# Altium schematic sheet Microcontroller.SchDoc
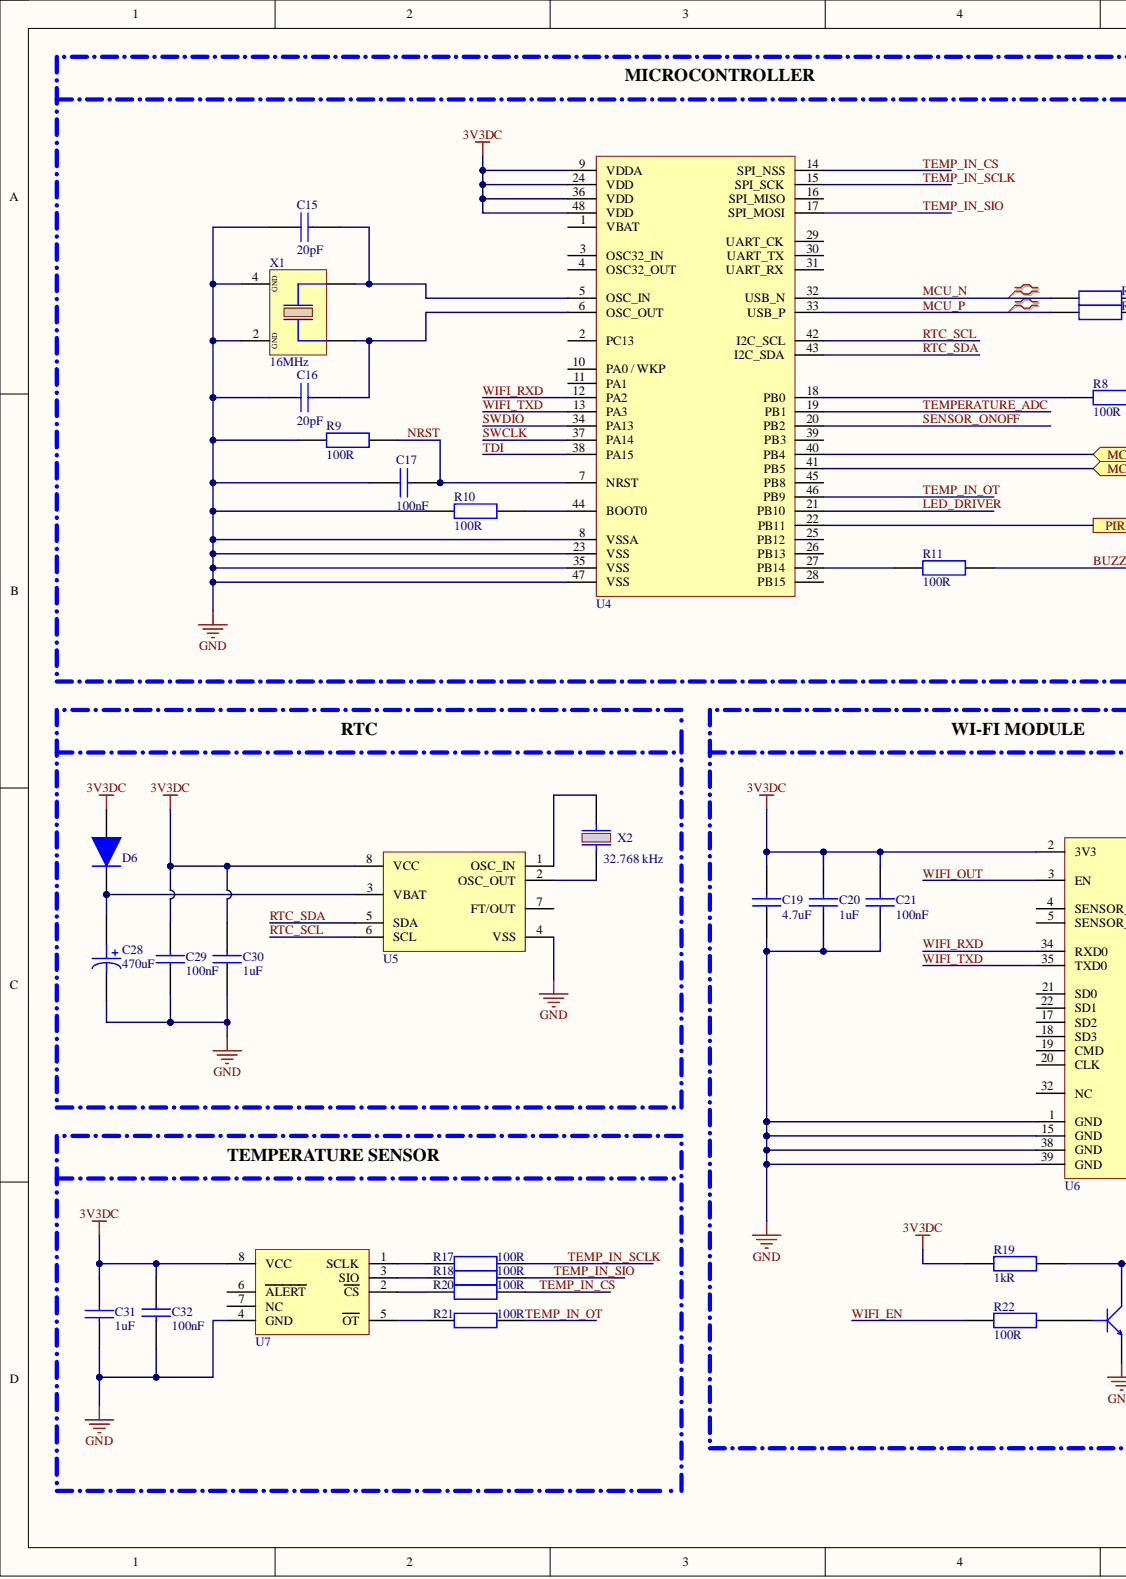
[diagram p0: Microcontroller.SchDoc, left]
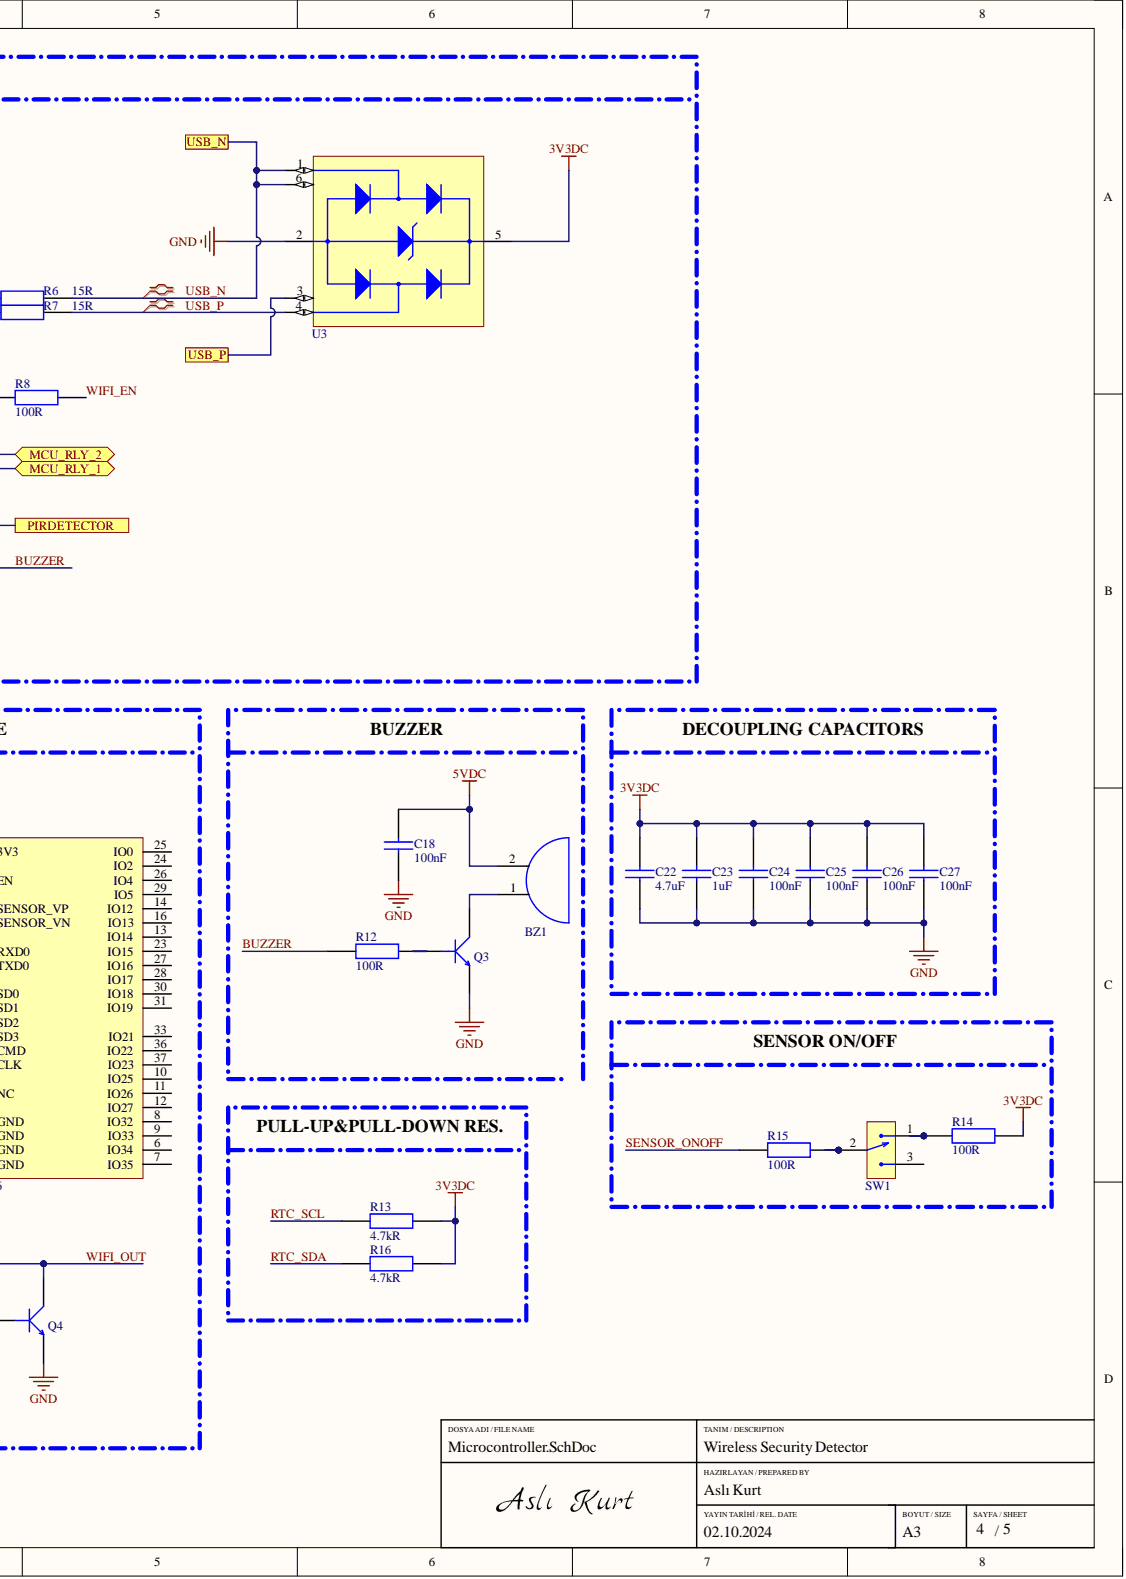
[diagram p1: Microcontroller.SchDoc, right]

## Parts
BZ1  CMI-9605IC-0580T  [CMI-9605IC]  (value not drawn)
C15  Capacitance: 20pF  [CAP_0603]  (hidden comment: CL10C200JB8NNNC)
C16  Capacitance: 20pF  [CAP_0603]  (hidden comment: CL10C200JB8NNNC)
C17  Capacitance: 100nF  [CAP_0603]  (hidden comment: C0603C104K5RACTU)
C18  Capacitance: 100nF  [CAP_0805]  (hidden comment: CL21B104KBCNNNC)
C19  Capacitance: 4.7uF  [CAP_0805]  (hidden comment: CL21A475KAQNNNE)
C20  Capacitance: 1uF  [CAP_0805]  (hidden comment: CL21B105KBFNNNE)
C21  Capacitance: 100nF  [CAP_0805]  (hidden comment: CL21B104KBCNNNC)
C22  Capacitance: 4.7uF  [CAP_0805]  (hidden comment: CL21A475KAQNNNE)
C23  Capacitance: 1uF  [CAP_0805]  (hidden comment: CL21B105KBFNNNE)
C24  Capacitance: 100nF  [CAP_0603]  (hidden comment: C0603C104K5RACTU)
C25  Capacitance: 100nF  [CAP_0603]  (hidden comment: C0603C104K5RACTU)
C26  Capacitance: 100nF  [CAP_0603]  (hidden comment: C0603C104K5RACTU)
C27  Capacitance: 100nF  [CAP_0603]  (hidden comment: C0603C104K5RACTU)
C28  Capacitance: 470uF  [CAP_RADIAL_D10_P5]  (hidden comment: ESH477M050AH4AA)
C29  Capacitance: 100nF  [CAP_0805]  (hidden comment: CL21B104KBCNNNC)
C30  Capacitance: 1uF  [CAP_0805]  (hidden comment: CL21B105KBFNNNE)
C31  Capacitance: 1uF  [CAP_0805]  (hidden comment: CL21B105KBFNNNE)
C32  Capacitance: 100nF  [CAP_0805]  (hidden comment: CL21B104KBCNNNC)
D6  1N4148WS  [SOD323F]  (value not drawn)
Q3  MMBT3904-7-F  [SOT-23F]  (value not drawn)
Q4  MMBT3904-7-F  [SOT-23F]  (value not drawn)
R6  Resistance: 15R  [RES_0603]  (hidden comment: RC0603JR-0715RL)
R7  Resistance: 15R  [RES_0603]  (hidden comment: RC0603JR-0715RL)
R8  Resistance: 100R  [RES_0603]  (hidden comment: RC0603JR-07100RL)
R9  Resistance: 100R  [RES_0603]  (hidden comment: RC0603JR-07100RL)
R10  Resistance: 100R  [RES_0603]  (hidden comment: RC0603JR-07100RL)
R11  Resistance: 100R  [RES_0603]  (hidden comment: RC0603JR-07100RL)
R12  Resistance: 100R  [RES_0603]  (hidden comment: RC0603JR-07100RL)
R13  Resistance: 4.7kR  [RES_0603]  (hidden comment: RC0603JR-074K7L)
R14  Resistance: 100R  [RES_0603]  (hidden comment: RC0603JR-07100RL)
R15  Resistance: 100R  [RES_0603]  (hidden comment: RC0603JR-07100RL)
R16  Resistance: 4.7kR  [RES_0603]  (hidden comment: RC0603JR-074K7L)
R17  Resistance: 100R  [RES_0603]  (hidden comment: RC0603JR-07100RL)
R18  Resistance: 100R  [RES_0603]  (hidden comment: RC0603JR-07100RL)
R19  Resistance: 1kR  [RES_0603]  (hidden comment: RC0603FR-0710KL)
R20  Resistance: 100R  [RES_0603]  (hidden comment: RC0603JR-07100RL)
R21  Resistance: 100R  [RES_0603]  (hidden comment: RC0603JR-07100RL)
R22  Resistance: 100R  [RES_0603]  (hidden comment: RC0603JR-07100RL)
SW1  EG1215AA  [EG1215AA]  (value not drawn)
U3  USBLC6-2SC6  [SOT23-6L]  (value not drawn)
U4  STM32F103C6T6A  [LQFP-48]  (value not drawn)
U5  M41T00M6F  [SOIC8]  (value not drawn)
U6  Supply Voltage-Nom: 3V3  [ESP32-32]  (hidden comment: ESP32-WROOM-32)
U7  MAX6662  [SOIC8]  (value not drawn)
X1  Frequency: 16MHz  [ECS]  (hidden comment: ECS-160-20-30B-TR)
X2  Operating Frequency: 32.768 kHz  [ABS05]  (hidden comment: ABS05-32.768KHZ-T)

## Ports
MCU_RLY_1, MCU_RLY_2, PIRDETECTOR, USB_N, USB_P

## Nets
3V3DC: C19.2 C20.2 C21.2 C22.2 C23.2 C24.2 C25.2 C26.2 C27.2 C29.2 C30.2 C31.2 C32.1 D6.2 R13.2 R14.2 R16.2 R19.1 U3.5 U4.9(VDDA) U4.24(VDD) U4.36(VDD) U4.48(VDD) U5.8(VCC) U6.2(3V3) U7.8(VCC)
5VDC: BZ1.2 C18.2
GND: C15.1 C16.1 C17.1 C18.1 C19.1 C20.1 C21.1 C22.1 C23.1 C24.1 C25.1 C26.1 C27.1 C28.2 C29.1 C30.1 C31.1 C32.2 Q3.2 Q4.2 R9.1 R10.1 U3.2 U4.8(VSSA) U4.23(VSS) U4.35(VSS) U4.47(VSS) U5.4(VSS) U6.1(GND) U6.15(GND) U6.38(GND) U6.39(GND) U7.4(GND) X1.2 X1.4
BUZZER: R11.2 R12.1
LED_DRIVER: U4.21(PB10)
MCU_N: R6.1 U4.32(USB_N)
MCU_P: R7.1 U4.33(USB_P)
MCU_RLY_1: U4.41(PB5) ; port MCU_RLY_1
MCU_RLY_2: U4.40(PB4) ; port MCU_RLY_2
NRST: C17.2 R9.2 U4.7(NRST)
PIRDETECTOR: U4.22(PB11) ; port PIRDETECTOR
RTC_SCL: R13.1 U4.42(I2C_SCL) U5.6(SCL)
RTC_SDA: R16.1 U4.43(I2C_SDA) U5.5(SDA)
SENSOR_ONOFF: R15.1 U4.20(PB2)
SWCLK: U4.37(PA14)
SWDIO: U4.34(PA13)
TDI: U4.38(PA15)
TEMP_IN_CS: R20.2 U4.14(SPI_NSS)
TEMP_IN_OT: R21.2 U4.46(PB9)
TEMP_IN_SCLK: R17.2 U4.15(SPI_SCK)
TEMP_IN_SIO: R18.2 U4.17(SPI_MOSI)
TEMPERATURE_ADC: U4.19(PB1)
USB_N: R6.2 U3.1 U3.6 ; port USB_N
USB_P: R7.2 U3.4
WIFI_EN: R8.2 R22.1
WIFI_OUT: Q4.3 R19.2 U6.3(EN)
WIFI_RXD: U4.12(PA2) U6.34(RXD0)
WIFI_TXD: U4.13(PA3) U6.35(TXD0)
NetBZ1_1: BZ1.1 Q3.3
NetC15_2: C15.2 U4.5(OSC_IN) X1.3
NetC16_2: C16.2 U4.6(OSC_OUT) X1.1
NetC28_1: C28.1 D6.1 U5.3(VBAT)
NetQ3_1: Q3.1 R12.2
NetQ4_1: Q4.1 R22.2
NetR8_1: R8.1 U4.18(PB0)
NetR10_2: R10.2 U4.44(BOOT0)
NetR11_1: R11.1 U4.27(PB14)
NetR14_1: R14.1 SW1.1
NetR15_2: R15.2 SW1.2
NetR17_1: R17.1 U7.1(SCLK)
NetR18_1: R18.1 U7.3(SIO)
NetR20_1: R20.1 U7.2(CS)
NetR21_1: R21.1 U7.5(OT)
NetU3_3: U3.3 ; port USB_P
NetU5_1: U5.1(OSC_IN) X2.2
NetU5_2: U5.2(OSC_OUT) X2.1

Not connected: SW1 3; U4 1(VBAT) 2(PC13) 3(OSC32_IN) 4(OSC32_OUT) 10(PA0_/_WKP) 11(PA1) 16(SPI_MISO) 25(PB12) 26(PB13) 28(PB15) 29(UART_CK) 30(UART_TX) 31(UART_RX) 39(PB3) 45(PB8); U5 7(FT/OUT); U6 4(SENSOR_VP) 5(SENSOR_VN) 6(IO34) 7(IO35) 8(IO32) 9(IO33) 10(IO25) 11(IO26) 12(IO27) 13(IO14) 14(IO12) 16(IO13) 17(SD2) 18(SD3) 19(CMD) 20(CLK) 21(SD0) 22(SD1) 23(IO15) 24(IO2) 25(IO0) 26(IO4) 27(IO16) 28(IO17) 29(IO5) 30(IO18) 31(IO19) 32(NC) 33(IO21) 36(IO22) 37(IO23); U7 6(ALERT) 7(NC)

## Library data of the parts
BZ1 = CMI-9605IC-0580T: Buzzers Indicator, Internally Driven Magnetic 5V 30mA 2.7kHz 80dB @ 5V, 10cm Through Hole PC Pins; Manufacturer: CUI Devices; Designed by: BERK; Case/Package: CMI-9605IC; Component Kind: Standard; Library Name: SECURITY_CAM.IntLib; Manufacturer Part Number: CMI-9605IC-0580T; Pricing 1: 1=1,41000, 10=1,17900, 25=1,09080, 50=1,02680, 100=0,96490, 500=0,83018, 1000=0,77805 (USD); RoHS: No; Stock 1: 1663; Supplier 1: Digi-Key; Supplier Part Number 1: 2223-CMI-9605IC-0580T-ND
C15 C16 = CAP CER 20pF 0603: CAP CER 20pF %5 0603 SMD; Manufacturer: Samsung; Designed by: BERK; Dielectric: C0G; Temperature Coefficient: 300ppm/°C; Tolerance: 5%; Voltage: 50V; Case Code (Metric): 1608; Case Code (Imperial): 0603; Case/Package: 0603; Category: Ceramic Capacitors; Component Kind: Standard; ComponentLink1Description: https://datasheet.ciiva.com/26877/getdatasheetpartid-640634-26877974.pdf?src-supplier=Verical; ComponentLink1URL: https://datasheet.ciiva.com/26877/getdatasheetpartid-640634-26877974.pdf?src-supplier=Verical; ComponentLink2Description: https://datasheet.ciiva.com/26310/mlcc-catalogdownload2013-eng-26310315.pdf?src-supplier=Distrelec; ComponentLink2URL: https://datasheet.ciiva.com/26310/mlcc-catalogdownload2013-eng-26310315.pdf?src-supplier=Distrelec; Construction: Flat; Depth: 0.8mm; Height: 0.8mm; Length: 1.6mm; Library Name: SECURITY_CAM.IntLib; Manufacturer Part Number: CL10C200JB8NNNC; Max Operating Temperature: 125°C; Min Operating Temperature: -55°C; Mount: Surface Mount; Package Quantity: 1; Packaging: Tape and Reel; Pricing 1: 1=0,10000, 10=0,04700, 50=0,02560, 100=0,02090, 500=0,01490, 1000=0,01171 (USD); Radiation Hardening: No; RoHS: Yes; RoHS Compliant: Yes; Series: CL; Stock 1: 765558; Supplier 1: Digi-Key; Supplier Part Number 1: 1276-1187-1-ND; Thickness: 0.035inch; Voltage Rating: 50V; Width: 0.031inch
C17 C24-C27 = CAP CER 100nF 0603: CAP CER 100nF %10 0603 SMD; Manufacturer: KEMET; Designed by: BERK; Dielectric: X7R; Dielectric Material: Ceramic; Dissipation Factor: 2.5%; Tolerance: 10%; Voltage: 50V; Case Code (Metric): 1608; Case Code (Imperial): 0603; Case/Package: 0603; Category: Ceramic Capacitors; Component Kind: Standard; ComponentLink1Description: https://datasheet.ciiva.com/7823/kem-c1002-x7r-smd-7823591.pdf?src-supplier=Element14; ComponentLink1URL: https://datasheet.ciiva.com/7823/kem-c1002-x7r-smd-7823591.pdf?src-supplier=Element14; ComponentLink2Description: https://datasheet.ciiva.com/14602/70095383-14602583.pdf?src-supplier=Allied+Electronics; ComponentLink2URL: https://datasheet.ciiva.com/14602/70095383-14602583.pdf?src-supplier=Allied+Electronics; ComponentLink3Description: https://datasheet.ciiva.com/5999/kem-c1002-x7r-smd-5999400.pdf?src-supplier=Farnell; ComponentLink3URL: https://datasheet.ciiva.com/5999/kem-c1002-x7r-smd-5999400.pdf?src-supplier=Farnell; ComponentLink4Description: https://datasheet.ciiva.com/7823/kem-c1002-x7r-smd-7823592.pdf?src-supplier=Element14; ComponentLink4URL: https://datasheet.ciiva.com/7823/kem-c1002-x7r-smd-7823592.pdf?src-supplier=Element14; ComponentLink5Description: https://datasheet.ciiva.com/28645/1851736-28645640.pdf?src-supplier=Element14; ComponentLink5URL: https://datasheet.ciiva.com/28645/1851736-28645640.pdf?src-supplier=Element14; ComponentLink6Description: https://datasheet.ciiva.com/14585/70095383-14585209.pdf?src-supplier=Allied+Electronics; ComponentLink6URL: https://datasheet.ciiva.com/14585/70095383-14585209.pdf?src-supplier=Allied+Electronics; Contact Plating: Tin,Matte; Depth: 0.8mm; Height: 0.8mm; Lead Pitch: 0.7mm; Length: 0.063inch; Library Name: SECURITY_CAM.IntLib; Manufacturer Part Number: C0603C104K5RACTU; Max Operating Temperature: 125°C; Min Operating Temperature: -55°C; Mount: Surface Mount; Number of Pins: 2; Package Quantity: 1; Packaging: Tape and Reel; Pricing 1: 1=0,12000, 10=0,08500, 50=0,04620, 100=0,03770, 500=0,02696, 1000=0,02118 (USD); Radiation Hardening: No; REACH SVHC: No SVHC; RoHS: Yes; RoHS Compliant: Yes; Series: SMD Comm X7R; Stock 1: 1666186; Supplier 1: Digi-Key; Supplier Part Number 1: 399-5089-1-ND; Termination: SMD/SMT; Thickness: 0.034inch; Voltage Rating: 50V; Voltage Rating (DC): 50V; Width: 0.031inch
C18 C21 C29 C32 = CAP CER 100nF 0805: CAP CER 100nF %10 0805; Manufacturer: Samsung; Designed by: BERK; Dielectric: X7R; Material: Ceramic; Tolerance: 10%; Voltage: 50V; Case Code (Metric): 2012; Case Code (Imperial): 0805; Case/Package: 0805; Category: Ceramic Capacitors; Component Kind: Standard; ComponentLink1Description: https://datasheet.ciiva.com/25710/cl21b104kbcnnnc-25710182.pdf?src-supplier=Digi-Key; ComponentLink1URL: https://datasheet.ciiva.com/25710/cl21b104kbcnnnc-25710182.pdf?src-supplier=Digi-Key; ComponentLink2Description: https://datasheet.ciiva.com/29199/454152-da-01-en-vs-kondensator-0805-29199814.pdf?src-supplier=Conrad; ComponentLink2URL: https://datasheet.ciiva.com/29199/454152-da-01-en-vs-kondensator-0805-29199814.pdf?src-supplier=Conrad; Construction: Flat; Contact Plating: Tin; Depth: 1.25mm; Height: 0.85mm; Lead Free: Lead Free; Length: 2mm; Library Name: SECURITY_CAM.IntLib; Manufacturer Part Number: CL21B104KBCNNNC; Max Operating Temperature: 125°C; Min Operating Temperature: -55°C; Mount: Surface Mount; Packaging: Tape and Reel; Pricing 1: 1=0,10000, 10=0,04700, 50=0,02560, 100=0,02090, 500=0,01494, 1000=0,01174 (USD); Radiation Hardening: No; RoHS: Yes; RoHS Compliant: Yes; Series: CL; Stock 1: 3371502; Supplier 1: Digi-Key; Supplier Part Number 1: 1276-1003-1-ND; Thickness: 0.037inch; Voltage Rating: 50V; Voltage Rating (DC): 50V; Width: 0.049inch
C19 C22 = CAP CER 4.7uF 0805: CAP CER 4.7uF %10 0805; Manufacturer: Samsung; Designed by: BERK; Dielectric: X5R; Tolerance: 10%; Voltage Rating: 25V; Case Code (Metric): 2012; Case Code (Imperial): 0805; Case/Package: 0805; Category: Ceramic Capacitors; Component Kind: Standard; ComponentLink1Description: https://datasheet.ciiva.com/25798/cl21a475kaqnnne-25798882.pdf?src-supplier=Digi-Key; ComponentLink1URL: https://datasheet.ciiva.com/25798/cl21a475kaqnnne-25798882.pdf?src-supplier=Digi-Key; Construction: Flat; Depth: 1.25mm; Height: 1.25mm; Lead Free: Lead Free; Length: 2mm; Library Name: SECURITY_CAM.IntLib; Manufacturer Part Number: CL21A475KAQNNNE; Max Operating Temperature: 85°C; Min Operating Temperature: -55°C; Mount: Surface Mount; Package Quantity: 1; Packaging: Tape and Reel; Pricing 1: 1=0,11000, 10=0,08100, 50=0,04580, 100=0,03800, 500=0,02664, 1000=0,02205 (USD); Radiation Hardening: No; RoHS: Yes; RoHS Compliant: Yes; Series: CL; Stock 1: 1477018; Supplier 1: Digi-Key; Supplier Part Number 1: 1276-1244-1-ND; Thickness: 0.055inch; Voltage Rating (DC): 25V; Width: 0.049inch
C20 C23 C30 C31 = CAP CER 1uF 0805: CAP CER 1uF %10 0805; Manufacturer: Samsung; Designed by: BERK; Dielectric: X7R; Tolerance: 10%; Voltage: 50V; Case Code (Metric): 2012; Case Code (Imperial): 0805; Case/Package: 0805; Category: Ceramic Capacitors; Component Kind: Standard; ComponentLink1Description: https://datasheet.ciiva.com/25800/cl21b105kbfnnne-25800291.pdf?src-supplier=Digi-Key; ComponentLink1URL: https://datasheet.ciiva.com/25800/cl21b105kbfnnne-25800291.pdf?src-supplier=Digi-Key; ComponentLink2Description: https://datasheet.ciiva.com/16449/cl21b105kbfnnne-16449003.pdf?src-supplier=Future+Electronics; ComponentLink2URL: https://datasheet.ciiva.com/16449/cl21b105kbfnnne-16449003.pdf?src-supplier=Future+Electronics; ComponentLink3Description: https://datasheet.ciiva.com/26838/getdatasheetpartid-354075-26838668.pdf?src-supplier=Verical; ComponentLink3URL: https://datasheet.ciiva.com/26838/getdatasheetpartid-354075-26838668.pdf?src-supplier=Verical; Construction: Flat; Depth: 1.25mm; Height: 1.25mm; Length: 2mm; Library Name: SECURITY_CAM.IntLib; Manufacturer Part Number: CL21B105KBFNNNE; Max Operating Temperature: 125°C; Min Operating Temperature: -55°C; Mount: Surface Mount; Package Quantity: 1; Packaging: Tape and Reel; Pricing 1: 2000=0,02138, 4000=0,01956 (USD); Radiation Hardening: No; RoHS: Yes; RoHS Compliant: Yes; Series: CL; Stock 1: 958000; Supplier 1: Digi-Key; Supplier Part Number 1: 1276-1029-2-ND; Thickness: 0.053inch; Voltage Rating: 50V; Width: 0.049inch
C28 = CAP ALU ELEC 470uF 50V: CAP ALU ELEC 470uF 50V RADIAL; Manufacturer: KEMET; Designed by: BERK; Life (Hours): 2000Hour; Polarity: Polar; Tolerance: 20%; Case/Package: Radial; Component Kind: Standard; ComponentLink1Description: https://api.kemet.com/component-edge/download/datasheet/ESH477M050AH4AA.pdf?src-supplier=Digi-Key; ComponentLink1URL: https://api.kemet.com/component-edge/download/datasheet/ESH477M050AH4AA.pdf?src-supplier=Digi-Key; Library Name: SECURITY_CAM.IntLib; Manufacturer Part Number: ESH477M050AH4AA; Max Operating Temperature: 105°C; Min Operating Temperature: -40°C; Mount: Through Hole; Packaging: Bulk; Pricing 1: 1=0,59000, 10=0,41700, 50=0,31540, 100=0,27470, 500=0,20350, 1000=0,17298, 2500=0,16280, 5000=0,15262 (USD); RoHS: No; RoHS Compliant: No; Stock 1: 1181; Supplier 1: Digi-Key; Supplier Part Number 1: 399-15683-ND; Voltage Rating: 50V
D6 = 1N4148WS: Diode, Single, 75 V, 150 mA, 1 V, 4 ns; Manufacturer: ON Semiconductor / Fairchild; Average Rectified Current: 150mA; Designed by: BERK; Forward Voltage: 1V; Max Forward Surge Current (Ifsm): 2A; Max Repetitive Reverse Voltage (Vrrm): 75V; Max Reverse Current: 5uA; Power Dissipation: 200mW; Recovery Time: 4ns; Category: Rectifier Diodes; Component Kind: Standard; ComponentLink1Description: https://datasheet.ciiva.com/25968/1n914bws-25968945.pdf?src-supplier=Avnet+Europe; ComponentLink1URL: https://datasheet.ciiva.com/25968/1n914bws-25968945.pdf?src-supplier=Avnet+Europe; ComponentLink2Description: https://datasheet.ciiva.com/30479/0900766b810e1cd3-30479206.pdf?src-supplier=RSComponents; ComponentLink2URL: https://datasheet.ciiva.com/30479/0900766b810e1cd3-30479206.pdf?src-supplier=RSComponents; ComponentLink3Description: https://datasheet.ciiva.com/910/1n4148ws-910381.pdf?src-supplier=Digi-Key; ComponentLink3URL: https://datasheet.ciiva.com/910/1n4148ws-910381.pdf?src-supplier=Digi-Key; ComponentLink4Description: https://datasheet.ciiva.com/15208/getdatasheetpartid-127819-15208358.pdf?src-supplier=Verical; ComponentLink4URL: https://datasheet.ciiva.com/15208/getdatasheetpartid-127819-15208358.pdf?src-supplier=Verical; ComponentLink5Description: https://datasheet.ciiva.com/8300/1n914bws-8300360.pdf?src-supplier=Avnet; ComponentLink5URL: https://datasheet.ciiva.com/8300/1n914bws-8300360.pdf?src-supplier=Avnet; ComponentLink6Description: https://datasheet.ciiva.com/910/1n4148ws-910380.pdf?src-supplier=Digi-Key; ComponentLink6URL: https://datasheet.ciiva.com/910/1n4148ws-910380.pdf?src-supplier=Digi-Key; ComponentLink7Description: https://datasheet.ciiva.com/910/1n4148ws-910382.pdf?src-supplier=Digi-Key; ComponentLink7URL: https://datasheet.ciiva.com/910/1n4148ws-910382.pdf?src-supplier=Digi-Key; Contact Plating: Tin,Matte; Element Configuration: Single; Forward Current: 150mA; Height: 1mm; Lead Free: Lead Free; Length: 1.8mm; Library Name: SECURITY_CAM.IntLib; Manufacturer Part Number: 1N4148WS; Max Operating Temperature: 150°C; Max Reverse Voltage (DC): 75V; Min Operating Temperature: -55°C; Mount: Surface Mount; Number of Pins: 2; Package Quantity: 1; Packaging: Tape and Reel; Pricing 1: 1=0,17000, 10=0,12100, 100=0,06530, 500=0,05128, 1000=0,03562 (USD); Radiation Hardening: No; REACH SVHC: No SVHC; Reverse Recovery Time: 4ns; Reverse Voltage: 75V; RoHS: Yes; RoHS Compliant: Yes; Series: -; Stock 1: 79118; Supplier 1: Digi-Key; Supplier Part Number 1: 1N4148WSFSCT-ND; Weight: 0.0276g; Width: 1.35mm
Q3 Q4 = MMBT3904-7-F: Transistor General Purpose BJT NPN 40 Volt 0.2A Automotive 3-Pin SOT-23; Manufacturer: Diodes Zetex; Collector Base Voltage (VCBO): 60V; Collector Emitter Breakdown Voltage: 40V; Collector Emitter Saturation Voltage: 300mV; Continuous Collector Current: 200mA; Designed by: BERK; Element Configuration: Single; Emitter Base Voltage (VEBO): 6V; Frequency: 300MHz; hFE Min: 100; Max Power Dissipation: 300mW; Number of Elements: 1; Power Dissipation: 350mW; Case/Package: SOT-23; Category: BJTs; Collector Emitter Voltage (VCEO): 40V; Collector-emitter Voltage-Max: 300mV; Component Kind: Standard; ComponentLink1Description: https://datasheet.ciiva.com/11201/ds30036-11201778.pdf?src-supplier=Avnet; ComponentLink1URL: https://datasheet.ciiva.com/11201/ds30036-11201778.pdf?src-supplier=Avnet; ComponentLink2Description: https://datasheet.ciiva.com/15138/getdatasheetpartid-53265-15138780.pdf?src-supplier=Verical; ComponentLink2URL: https://datasheet.ciiva.com/15138/getdatasheetpartid-53265-15138780.pdf?src-supplier=Verical; ComponentLink3Description: https://datasheet.ciiva.com/6361/ds30036-6361254.pdf?src-supplier=Digi-Key; ComponentLink3URL: https://datasheet.ciiva.com/6361/ds30036-6361254.pdf?src-supplier=Digi-Key; ComponentLink4Description: https://datasheet.ciiva.com/22711/1915516-22711750.pdf?src-supplier=Element14; ComponentLink4URL: https://datasheet.ciiva.com/22711/1915516-22711750.pdf?src-supplier=Element14; ComponentLink5Description: https://datasheet.ciiva.com/11198/ds30036-11198999.pdf?src-supplier=Avnet; ComponentLink5URL: https://datasheet.ciiva.com/11198/ds30036-11198999.pdf?src-supplier=Avnet; ComponentLink6Description: https://datasheet.ciiva.com/6361/ds30036-6361256.pdf?src-supplier=Digi-Key; ComponentLink6URL: https://datasheet.ciiva.com/6361/ds30036-6361256.pdf?src-supplier=Digi-Key; Contact Plating: Tin,Matte; Gain Bandwidth Product: 300MHz; Height: 1mm; Lead Free: Lead Free; Length: 3.05mm; Library Name: SECURITY_CAM.IntLib; Manufacturer Part Number: MMBT3904-7-F; Max Breakdown Voltage: 40V; Max Collector Current: 200mA; Max Frequency: 300MHz; Max Operating Temperature: 150°C; Min Operating Temperature: -55°C; Mount: Surface Mount; Number of Pins: 3; Package Quantity: 3000; Packaging: Tape and Reel; Polarity: NPN; Pricing 1: 1=0,12000, 10=0,08600, 25=0,08280, 100=0,04660, 500=0,03664, 1000=0,02544 (USD); Radiation Hardening: No; REACH SVHC: No SVHC; RoHS: Yes; RoHS Compliant: Yes; Series: -; Stock 1: 2393281; Supplier 1: Digi-Key; Supplier Part Number 1: MMBT3904-FDIDKR-ND; Transition Frequency: 300MHz; Voltage Rating (DC): 40V; Weight: 0.000282oz; Width: 1.4mm
R6 R7 = RES 15 OHM 0603: RES 15 %5 OHM 0603 SMD; Manufacturer: Yageo; Designed by: BERK; Max Power Dissipation: 100mW; Resistance Tolerance: 5%; Temperature Coefficient: 100ppm/°C; Voltage Rating: 75V; Voltage Rating (DC): 50V; Case Code (Metric): 1608; Case Code (Imperial): 0603; Case/Package: 0603; Category: Chip SMD Resistors; Component Kind: Standard; ComponentLink1Description: https://datasheet.ciiva.com/2453/pyu-r-int-thick-7-2453085.pdf?src-supplier=Digi-Key; ComponentLink1URL: https://datasheet.ciiva.com/2453/pyu-r-int-thick-7-2453085.pdf?src-supplier=Digi-Key; ComponentLink2Description: https://datasheet.ciiva.com/7746/443971-7746445.pdf?src-supplier=Element14; ComponentLink2URL: https://datasheet.ciiva.com/7746/443971-7746445.pdf?src-supplier=Element14; ComponentLink3Description: https://datasheet.ciiva.com/2453/pyu-r-int-thick-7-2453086.pdf?src-supplier=Digi-Key; ComponentLink3URL: https://datasheet.ciiva.com/2453/pyu-r-int-thick-7-2453086.pdf?src-supplier=Digi-Key; ComponentLink4Description: https://datasheet.ciiva.com/7746/443971-7746446.pdf?src-supplier=Element14; ComponentLink4URL: https://datasheet.ciiva.com/7746/443971-7746446.pdf?src-supplier=Element14; ComponentLink5Description: https://datasheet.ciiva.com/2453/pyu-r-int-thick-7-2453087.pdf?src-supplier=Digi-Key; ComponentLink5URL: https://datasheet.ciiva.com/2453/pyu-r-int-thick-7-2453087.pdf?src-supplier=Digi-Key; Construction: Rectangular; Depth: 0.8mm; Features: Moisture Resistant; Height: 0.022inch; Lead Free: Lead Free; Length: 1.6mm; Library Name: SECURITY_CAM.IntLib; Manufacturer Part Number: RC0603JR-0715RL; Max Operating Temperature: 155°C; Military Standard: Not; Min Operating Temperature: -55°C; Number of Pins: 2; Number of Terminations: 2; Package Quantity: 1; Packaging: Tape and Reel; Power Rating: 100mW; Pricing 1: 1=0,10000, 10=0,01900, 100=0,00770, 1000=0,00344, 2500=0,00298 (USD); REACH SVHC: No SVHC; Resistor Type: Thick Film; RoHS: Yes; RoHS Compliant: Yes; Series: RC; Stock 1: 1720; Supplier 1: Digi-Key; Supplier Part Number 1: 311-15GRCT-ND; Termination: SMD/SMT; Tolerance: 5%; Weight: 7.1E-05oz; Width: 0.8mm; Working Voltage: 75V
R8-R12 R14 R15 R17 R18 R20-R22 = RES 100 OHM 0603: RES 100 %5 OHM 0603 SMD; Manufacturer: Yageo; Designed by: BERK; Max Power Dissipation: 100mW; Resistance Tolerance: 5%; Temperature Coefficient: 100ppm/°C; Voltage Rating: 50V; Case Code (Metric): 1608; Case Code (Imperial): 0603; Case/Package: 0603; Category: Chip SMD Resistors; Component Kind: Standard; ComponentLink1Description: https://datasheet.ciiva.com/15189/getdatasheetpartid-325207-15189846.pdf?src-supplier=Verical; ComponentLink1URL: https://datasheet.ciiva.com/15189/getdatasheetpartid-325207-15189846.pdf?src-supplier=Verical; ComponentLink2Description: https://datasheet.ciiva.com/8883/pyu-rc0603-51-rohs-l-4-8883047.pdf?src-supplier=Avnet; ComponentLink2URL: https://datasheet.ciiva.com/8883/pyu-rc0603-51-rohs-l-4-8883047.pdf?src-supplier=Avnet; ComponentLink3Description: https://datasheet.ciiva.com/7746/443971-7746425.pdf?src-supplier=Element14; ComponentLink3URL: https://datasheet.ciiva.com/7746/443971-7746425.pdf?src-supplier=Element14; ComponentLink4Description: https://datasheet.ciiva.com/7746/443971-7746426.pdf?src-supplier=Element14; ComponentLink4URL: https://datasheet.ciiva.com/7746/443971-7746426.pdf?src-supplier=Element14; Construction: Rectangular; Contact Plating: Tin,Matte; Depth: 0.8mm; Features: Moisture Resistant; Lead Free: Lead Free; Length: 1.6mm; Library Name: SECURITY_CAM.IntLib; Manufacturer Part Number: RC0603JR-07100RL; Max Operating Temperature: 155°C; Military Standard: Not; Min Operating Temperature: -55°C; Number of Pins: 2; Number of Terminations: 2; Packaging: Tape and Reel; Power Rating: 100mW; Pricing 1: 1=0,10000, 10=0,01900, 100=0,00770, 1000=0,00344, 2500=0,00298 (USD); Radiation Hardening: No; REACH SVHC: Unknown; Resistor Type: Thick Film; RoHS: Yes; RoHS Compliant: Yes; Series: RC; Size Code: 0603; Stock 1: 4334796; Supplier 1: Digi-Key; Supplier Part Number 1: 311-100GRCT-ND; Termination: SMD/SMT; Tolerance: 5%; Voltage Rating (DC): 50V; Width: 0.8mm
R13 R16 = RES 4K7 OHM 0603: RES 4K7 %5 OHM 0603 SMD; Manufacturer: Yageo; Designed by: BERK; Max Power Dissipation: 100mW; Resistance Tolerance: 5%; Temperature Coefficient: 100ppm/°C; Voltage Rating: 50V; Case Code (Metric): 1608; Case Code (Imperial): 0603; Case/Package: 0603; Category: Chip SMD Resistors; Component Kind: Standard; ComponentLink1Description: https://datasheet.ciiva.com/46412/443971-46412858.pdf?src-supplier=Element14; ComponentLink1URL: https://datasheet.ciiva.com/46412/443971-46412858.pdf?src-supplier=Element14; Construction: Rectangular; Depth: 0.8mm; Features: Moisture Resistant; Lead Free: Lead Free; Length: 1.6mm; Library Name: SECURITY_CAM.IntLib; Manufacturer Part Number: RC0603JR-074K7L; Max Operating Temperature: 155°C; Military Standard: Not; Min Operating Temperature: -55°C; Number of Pins: 2; Number of Terminations: 2; Packaging: Tape and Reel; Power Rating: 100mW; Pricing 1: 1=0,10000, 10=0,01900, 100=0,00770, 1000=0,00344, 2500=0,00298 (USD); REACH SVHC: No SVHC; Resistor Type: Thick Film; RoHS: Yes; RoHS Compliant: Yes; Series: RC; Size Code: 0603; Stock 1: 3901839; Supplier 1: Digi-Key; Supplier Part Number 1: 311-4.7KGRCT-ND; Termination: SMD/SMT; Tolerance: 5%; Voltage Rating (DC): 50V; Width: 0.8mm
R19 = RES 1K OHM 0603: RES 1K %1 OHM 0603 SMD; Manufacturer: Yageo; Manufacturer Part Number: RC0603JR-071KL; Body Length: 1.6mm; Designed by: BERK; Power Rating: 100mW; Resistance Tolerance: 5%; Temperature Coefficient: 100ppm/°C; Voltage Rating: 50V; Case Code (Metric): 1608; Case Code (Imperial): 0603; Case/Package: 0603; Category: Chip SMD Resistors; Component Kind: Standard; ComponentLink1Description: https://datasheet.ciiva.com/8883/pyu-rc0603-51-rohs-l-4-8883051.pdf?src-supplier=Avnet; ComponentLink1URL: https://datasheet.ciiva.com/8883/pyu-rc0603-51-rohs-l-4-8883051.pdf?src-supplier=Avnet; ComponentLink2Description: https://datasheet.ciiva.com/7746/443971-7746461.pdf?src-supplier=Element14; ComponentLink2URL: https://datasheet.ciiva.com/7746/443971-7746461.pdf?src-supplier=Element14; ComponentLink3Description: https://datasheet.ciiva.com/22747/443971-22747533.pdf?src-supplier=Element14; ComponentLink3URL: https://datasheet.ciiva.com/22747/443971-22747533.pdf?src-supplier=Element14; ComponentLink4Description: https://datasheet.ciiva.com/7746/443971-7746462.pdf?src-supplier=Element14; ComponentLink4URL: https://datasheet.ciiva.com/7746/443971-7746462.pdf?src-supplier=Element14; Construction: Rectangular; Contact Plating: Tin,Matte; Depth: 0.8mm; Height: 0.45mm; Lead Free: Lead Free; Length: 1.6mm; Library Name: SECURITY_CAM.IntLib; Max Operating Temperature: 155°C; Military Standard: Not; Min Operating Temperature: -55°C; Number of Pins: 2; Package Quantity: 5000; Packaging: Tape and Reel; Pricing 1: 1=0,10000, 10=0,01900, 100=0,00770, 1000=0,00344, 2500=0,00298 (USD); Radiation Hardening: No; REACH SVHC: Unknown; Resistor Type: Thick Film; RoHS: Yes; RoHS Compliant: Yes; Series: RC; Size Code: 0603; Stock 1: 5112056; Supplier 1: Digi-Key; Supplier Part Number 1: 311-1.0KGRCT-ND; Termination: SMD/SMT; Tolerance: 5%; Voltage Rating (DC): 50V; Width: 0.8mm
SW1 = EG1215AA: Slide Switch, SPDT, 0.025A, 24V, SMD; Manufacturer: E-Switch; Actuator Length: 1.4mm; Actuator Type: Standard; Current Rating: 25mA; Designed by: BERK; Electrical Life: 10000Cycles; Max Power Dissipation: 5W; Operating Force: 150gf; Switch Function: On-On; Switch Travel: 1.5mm; Tolerance: 4.95%; Zener Voltage: 9.1V; Impedance: 2R; Case/Package: Axial; Component Kind: Standard; ComponentLink1Description: https://media.digikey.com/pdf/Data%20Sheets/E-Switch%20PDFs/EG1215_Series_Ds.pdf?src-supplier=Digi-Key; ComponentLink1URL: https://media.digikey.com/pdf/Data%20Sheets/E-Switch%20PDFs/EG1215_Series_Ds.pdf?src-supplier=Digi-Key; ComponentLink2Description: http://www.farnell.com/datasheets/2645007.pdf?src-supplier=Farnell; ComponentLink2URL: http://www.farnell.com/datasheets/2645007.pdf?src-supplier=Farnell; Library Name: SECURITY_CAM.IntLib; Manufacturer Part Number: EG1215AA; Max Operating Temperature: 175°C; Mechanical Life: 10000Cycles; Min Operating Temperature: -20°C; Mount: Surface Mount,Through Hole; Packaging: Cut Tape; Pricing 1: 1=0,85000, 10=0,81900, 25=0,76560, 100=0,66470, 250=0,60480, 500=0,56070, 1000=0,51975 (USD); RoHS: No; Stock 1: 6245; Supplier 1: Digi-Key; Supplier Part Number 1: EG5720CT-ND; Termination: Gull Wing; Throw Configuration: SPDT; Voltage Rating (DC): 24V
U3 = USB PROTECTION: TVS DIODE 5.25V 17V SOT23-6; Manufacturer: STMicroelectronics; Breakdown Voltage: 6V; Capacitance: 3.5pF; Data Rate: 480Mbps; Designed by: BERK; Direction: Unidirectional,Bidirectional; Element Configuration: Dual; ESD Protection: Yes; Leakage Current: 150nA; Number of Bidirectional Channels: 2; Number of Elements: 1; Operating Supply Voltage: 1.1V; Peak Pulse Current: 5A; Test Current: 1mA; Working Voltage: 5V; Case/Package: SOT-23; Category: TVS Diodes; Clamping Voltage: 17V; Clamping Voltage-Max: 17V; Component Kind: Standard; ComponentLink1Description: https://datasheet.ciiva.com/1268/1690354-1268384.pdf?src-supplier=Element14; ComponentLink1URL: https://datasheet.ciiva.com/1268/1690354-1268384.pdf?src-supplier=Element14; ComponentLink2Description: https://datasheet.ciiva.com/4508/cd00050750-113117-4508433.pdf?src-supplier=Mouser; ComponentLink2URL: https://datasheet.ciiva.com/4508/cd00050750-113117-4508433.pdf?src-supplier=Mouser; ComponentLink3Description: https://datasheet.ciiva.com/15143/getdatasheetpartid-148465-15143789.pdf?src-supplier=Verical; ComponentLink3URL: https://datasheet.ciiva.com/15143/getdatasheetpartid-148465-15143789.pdf?src-supplier=Verical; ComponentLink4Description: https://datasheet.ciiva.com/26787/usblc6-2sc6-26787908.pdf?src-supplier=IIIC; ComponentLink4URL: https://datasheet.ciiva.com/26787/usblc6-2sc6-26787908.pdf?src-supplier=IIIC; ComponentLink5Description: https://datasheet.ciiva.com/16200/cd00050750-16200026.pdf?src-supplier=Future+Electronics; ComponentLink5URL: https://datasheet.ciiva.com/16200/cd00050750-16200026.pdf?src-supplier=Future+Electronics; ComponentLink6Description: https://datasheet.ciiva.com/41444/001184109-da-01-en-ic-esd-protecti-usblc6-2sc6-sot-23-6-stm-41444156.pdf?src-supplier=Conrad; ComponentLink6URL: https://datasheet.ciiva.com/41444/001184109-da-01-en-ic-esd-protecti-usblc6-2sc6-sot-23-6-stm-41444156.pdf?src-supplier=Conrad; Contact Plating: Tin,Matte; Depth: 1.75mm; Height: 1.3mm; Lead Free: Lead Free; Length: 3.05mm; Library Name: SECURITY_CAM.IntLib; Manufacturer Part Number: USBLC6-2SC6; Max Breakdown Voltage: 6V; Max Operating Temperature: 125°C; Max Reverse Leakage Current: 150nA; Min Breakdown Voltage: 6V; Min Operating Temperature: -40°C; Mount: Surface Mount; Number of Channels: 2; Number of Pins: 6; Package Quantity: 3000; Packaging: Tape and Reel; Polarity: Unidirectional,Bidirectional; Power Line Protection: Yes; Pricing 1: 1=0,50000, 10=0,43100, 100=0,29930, 500=0,23368, 1000=0,19005 (USD); Radiation Hardening: No; REACH SVHC: No SVHC; Reverse Breakdown Voltage: 6V; Reverse Standoff Voltage: 5.25V; RoHS: Yes; RoHS Compliant: Yes; Series: USB; Stock 1: 112981; Supplier 1: Digi-Key; Supplier Part Number 1: 497-5235-1-ND; Termination: SMD/SMT; Width: 1.75mm
U4 = STM32F103C6T6A: MCU 32-bit STM32F ARM Cortex M3 RISC 32KB Flash 2.5V/3.3V 48-Pin LQFP Tray; Manufacturer: STMicroelectronics; Address Bus Width: 32b; Designed by: BERK; Frequency: 72MHz; Max Power Dissipation: 363mW; Max Supply Voltage: 3.6V; Min Supply Voltage: 2V; Number of ADC Channels: 10; Number of Programmable I/O: 37; Number of SPI Channels: 1; Number of Timers/Counters: 3; Oscillator Type: Internal; Peripherals: POR,PWM,WDT,DMA,Temp Sensor,I2C; RAM Size: 10KB; SleepMode: OK; Watchdog Timer: Yes; Interface: I2C,SPI,USART; Case/Package: LQFP; Category: Microcontrollers; Component Kind: Standard; ComponentLink1Description: https://datasheet.ciiva.com/15122/getdatasheetpartid-69879-15122075.pdf?src-supplier=Verical; ComponentLink1URL: https://datasheet.ciiva.com/15122/getdatasheetpartid-69879-15122075.pdf?src-supplier=Verical; ComponentLink2Description: https://datasheet.ciiva.com/14100/pustm-arm-microcont-stm32f103-en-14100507.pdf?src-supplier=Distrelec; ComponentLink2URL: https://datasheet.ciiva.com/14100/pustm-arm-microcont-stm32f103-en-14100507.pdf?src-supplier=Distrelec; Core Architecture: ARM; Data Bus Width: 32b; Height: 1.4mm; Lead Free: Lead Free; Length: 7mm; Library Name: SECURITY_CAM.IntLib; Manufacturer Part Number: STM32F103C6T6A; Max Frequency: 72MHz; Max Operating Temperature: 85°C; Memory Size: 32KB; Memory Type: FLASH; Min Operating Temperature: -40°C; Number of Pins: 48; Number of I2C Channels: 1; Number of I/Os: 37; Package Quantity: 1500; Packaging: Tray; Pricing 1: 1=5,07000, 10=4,55000, 25=4,30120, 80=3,72763, 250=3,53648, 500=3,18600 (USD); Pricing 2: 250=1,99138, 260=1,95951, 510=1,91172, 1300=1,87986, 2500=1,84800, 13000=1,81613, 25000=1,78427 (USD); Radiation Hardening: No; REACH SVHC: No SVHC; RoHS: Yes; RoHS Compliant: Yes; Series: STM32F103C6; Stock 1: 30; Stock 2: 2360; Supplier 1: Digi-Key; Supplier 2: Avnet; Supplier Part Number 1: 497-11515-ND; Supplier Part Number 2: STM32F103C6T6A; Weight: 0.006409oz; Width: 7mm
U5 = M41T00M6F: IC RTC CLK/CALENDAR I2C 8-SOIC; Manufacturer: STMicroelectronics; Date Format: YY-MM-DD-dd; Designed by: BERK; Logic Function: Clock; Max Supply Voltage: 5.5V; Memory Size: 8B; Min Supply Voltage: 2V; Time Format: HH:MM:SS; Interface: I2C,Serial,2-Wire; Case/Package: SOIC; Category: Memory; Component Kind: Standard; ComponentLink1Description: https://datasheet.ciiva.com/25889/cd00001542-25889923.pdf?src-supplier=Avnet+Europe; ComponentLink1URL: https://datasheet.ciiva.com/25889/cd00001542-25889923.pdf?src-supplier=Avnet+Europe; ComponentLink2Description: https://datasheet.ciiva.com/16213/cd00001542-16213654.pdf?src-supplier=Future+Electronics; ComponentLink2URL: https://datasheet.ciiva.com/16213/cd00001542-16213654.pdf?src-supplier=Future+Electronics; ComponentLink3Description: https://datasheet.ciiva.com/7630/1837112-7630077.pdf?src-supplier=Element14; ComponentLink3URL: https://datasheet.ciiva.com/7630/1837112-7630077.pdf?src-supplier=Element14; ComponentLink4Description: https://datasheet.ciiva.com/41459/001185345-da-01-en-ic-rtc-srl-512kbit-m41t00m6f-soic-8-stm-41459818.pdf?src-supplier=Conrad; ComponentLink4URL: https://datasheet.ciiva.com/41459/001185345-da-01-en-ic-rtc-srl-512kbit-m41t00m6f-soic-8-stm-41459818.pdf?src-supplier=Conrad; Height: 1.5mm; Lead Free: Lead Free; Length: 5mm; Library Name: SECURITY_CAM.IntLib; Manufacturer Part Number: M41T00M6F; Max Operating Temperature: 85°C; Min Operating Temperature: -40°C; Mount: Surface Mount; Non-volatile Memory Size: 8B; Number of Pins: 8; Package Quantity: 2500; Packaging: Tape and Reel; Pricing 1: 1=2,81000, 10=2,52200, 25=2,37960, 100=2,02750, 250=1,90376, 500=1,79600 (USD); Radiation Hardening: No; RAM Size: 8B; REACH SVHC: No SVHC; RoHS: Yes; RoHS Compliant: Yes; Series: M41T00; Stock 1: 13199; Supplier 1: Digi-Key; Supplier Part Number 1: 497-4697-1-ND; Supply Voltage-Nom: 5.5V; Temperature Grade: INDUSTRIAL; Weight: 0.019048oz; Width: 4mm
U6 = ESP32-WROOM-32: SMD MODULE, ESP32-D0WDQ6, 32MBIT; Manufacturer: Espressif Systems; Data Rate: 150Mbps; Designed by: BERK; Frequency: 2.5GHz; Operating Supply Voltage: 3.6V; Sensitivity: -98dBm; Case/Package: SMD/SMT; Category: RF Receivers, Transceivers; Component Kind: Standard; ComponentLink1Description: https://datasheet.ciiva.com/70828/esp32-wroom-32-datasheet-en-70828769.pdf?src-supplier=Digi-Key; ComponentLink1URL: https://datasheet.ciiva.com/70828/esp32-wroom-32-datasheet-en-70828769.pdf?src-supplier=Digi-Key; ComponentLink2Description: https://datasheet.ciiva.com/69725/esp32-datasheet-en-69725253.pdf?src-supplier=Digi-Key; ComponentLink2URL: https://datasheet.ciiva.com/69725/esp32-datasheet-en-69725253.pdf?src-supplier=Digi-Key; Library Name: SECURITY_CAM.IntLib; Manufacturer Part Number: ESP32-WROOM-32; Max Operating Temperature: +85; Min Operating Temperature: -40; Mount: Surface Mount; Package Quantity: 550; Packaging: Cut Tape; Pricing 1: 1=3,80000 (USD); RoHS: No; Supplier 1: Digi-Key; Supplier Part Number 1: 1904-1010-1-ND; Weight: 0.088185oz
U7 = MAX6662: SENSOR TEMPERATURE SPI 8SOIC; Manufacturer: Maxim; Manufacturer Part Number: MAX6662MSA+; Designed by: BERK; Max Power Dissipation: 471mW; Max Supply Voltage: 5.5V; Min Supply Voltage: 3V; Number of Bits: 12; Operating Supply Current: 600uA; Output Type: Digital,SPI; Resolution: 12b; Case/Package: SOIC; Category: Temperature and Humidity Sensors; Component Kind: Standard; ComponentLink1Description: https://datasheet.ciiva.com/5299/max6662-89142-5299456.pdf?src-supplier=Mouser; ComponentLink1URL: https://datasheet.ciiva.com/5299/max6662-89142-5299456.pdf?src-supplier=Mouser; ComponentLink2Description: https://datasheet.ciiva.com/16635/max6662-16635842.pdf?src-supplier=Avnet+Europe; ComponentLink2URL: https://datasheet.ciiva.com/16635/max6662-16635842.pdf?src-supplier=Avnet+Europe; ComponentLink3Description: https://datasheet.ciiva.com/3277/max6662-3277979.pdf?src-supplier=Avnet; ComponentLink3URL: https://datasheet.ciiva.com/3277/max6662-3277979.pdf?src-supplier=Avnet; ComponentLink4Description: https://datasheet.ciiva.com/2003/max6662-2003390.pdf?src-supplier=Digi-Key; ComponentLink4URL: https://datasheet.ciiva.com/2003/max6662-2003390.pdf?src-supplier=Digi-Key; Height: 1.5mm; Lead Free: Lead Free; Length: 5mm; Library Name: SECURITY_CAM.IntLib; Max Operating Temperature: 150°C; Max Supply Voltage (DC): 5.5V; Min Operating Temperature: -55°C; Min Supply Voltage (DC): 3V; Number of Pins: 8; Package Quantity: 100; Packaging: Rail/Tube; Pricing 1: 1=3,20000, 10=2,87000, 25=2,71360, 100=2,35170, 500=2,00196, 1000=1,68840, 5000=1,64820 (USD); Radiation Hardening: No; REACH SVHC: Unknown; RoHS: Yes; RoHS Compliant: Yes; Series: MAX6662; Stock 1: 753; Supplier 1: Digi-Key; Supplier Part Number 1: MAX6662MSA+-ND; Width: 4mm; Interface: SPI
X1 = CRYSTAL 16MHz: CRYSTAL 16.0000MHZ 20PF SMD; Manufacturer: ECS International; Designed by: BERK; ESR (Equivalent Series Resistance): 50R; Frequency Stability: 50ppm; Frequency Tolerance: 30ppm; Case/Package: SMD/SMT; Category: Crystals; Component Kind: Standard; ComponentLink1Description: https://datasheet.ciiva.com/853/ecx-53b-853408.pdf?src-supplier=Digi-Key; ComponentLink1URL: https://datasheet.ciiva.com/853/ecx-53b-853408.pdf?src-supplier=Digi-Key; ComponentLink2Description: https://datasheet.ciiva.com/853/ecx-53b-853406.pdf?src-supplier=Digi-Key; ComponentLink2URL: https://datasheet.ciiva.com/853/ecx-53b-853406.pdf?src-supplier=Digi-Key; ComponentLink3Description: https://datasheet.ciiva.com/853/ecx-53b-853407.pdf?src-supplier=Digi-Key; ComponentLink3URL: https://datasheet.ciiva.com/853/ecx-53b-853407.pdf?src-supplier=Digi-Key; Height: 0.033inch; Lead Free: Lead Free; Length: 0.197inch; Library Name: SECURITY_CAM.IntLib; Load Capacitance: 20pF; Manufacturer Part Number: ECS-160-20-30B-TR; Max Operating Temperature: 70°C; Min Operating Temperature: -20°C; Mount: Surface Mount; Package Quantity: 1; Packaging: Tape and Reel; Pricing 1: 1=1,33000, 10=1,26800, 50=1,23900, 100=1,06200, 500=1,03250 (USD); RoHS: Yes; RoHS Compliant: Yes; Series: ECX-53B; Stock 1: 15303; Supplier 1: Digi-Key; Supplier Part Number 1: XC1120CT-ND; Termination: SMD/SMT; Tolerance: 50ppm; Width: 0.126inch
X2 = CRYSTAL 32768kHZ: Crystal, 32.768 kHz, SMD, 1.6mm x 1mm, 12.5 pF, 20 ppm, ABS05 Series; Manufacturer: Abracon; Designed by: BERK; ESR (Equivalent Series Resistance): 90kR; Frequency Tolerance: 20ppm; Load Capacitance: 12.5pF; Case/Package: SMD/SMT; Category: Crystals; Component Kind: Standard; ComponentLink1Description: https://datasheet.ciiva.com/23810/abs05-23810480.pdf?src-supplier=Newark; ComponentLink1URL: https://datasheet.ciiva.com/23810/abs05-23810480.pdf?src-supplier=Newark; ComponentLink2Description: https://datasheet.ciiva.com/6728/abs05-6728949.pdf?src-supplier=Digi-Key; ComponentLink2URL: https://datasheet.ciiva.com/6728/abs05-6728949.pdf?src-supplier=Digi-Key; ComponentLink3Description: https://datasheet.ciiva.com/6728/abs05-6728950.pdf?src-supplier=Digi-Key; ComponentLink3URL: https://datasheet.ciiva.com/6728/abs05-6728950.pdf?src-supplier=Digi-Key; ComponentLink4Description: https://datasheet.ciiva.com/16411/abs05-16411149.pdf?src-supplier=Future+Electronics; ComponentLink4URL: https://datasheet.ciiva.com/16411/abs05-16411149.pdf?src-supplier=Future+Electronics; Depth: 1mm; Frequency: 32.768kHz; Height: 0.02inch; Lead Free: Lead Free; Length: 1.6mm; Library Name: SECURITY_CAM.IntLib; Manufacturer Part Number: ABS05-32.768KHZ-T; Max Operating Temperature: 85°C; Min Operating Temperature: -40°C; Mount: Surface Mount; Number of Pins: 2; Package Quantity: 3000; Packaging: Tape and Reel; Pricing 1: 1=1,16000, 10=1,02500, 50=0,95760, 100=0,84630, 500=0,80180, 1000=0,66816 (USD); Radiation Hardening: No; REACH SVHC: No SVHC; RoHS: Yes; RoHS Compliant: Yes; Series: ABS05; Series Resistance: 90kR; Stock 1: 180522; Supplier 1: Digi-Key; Supplier Part Number 1: 535-11899-1-ND; Termination: SMD/SMT; Tolerance: 20ppm; Width: 0.039inch
